# Altium schematic sheet D5200_V002_3_20180610.SchDoc(D5200_V002_3_20180610)
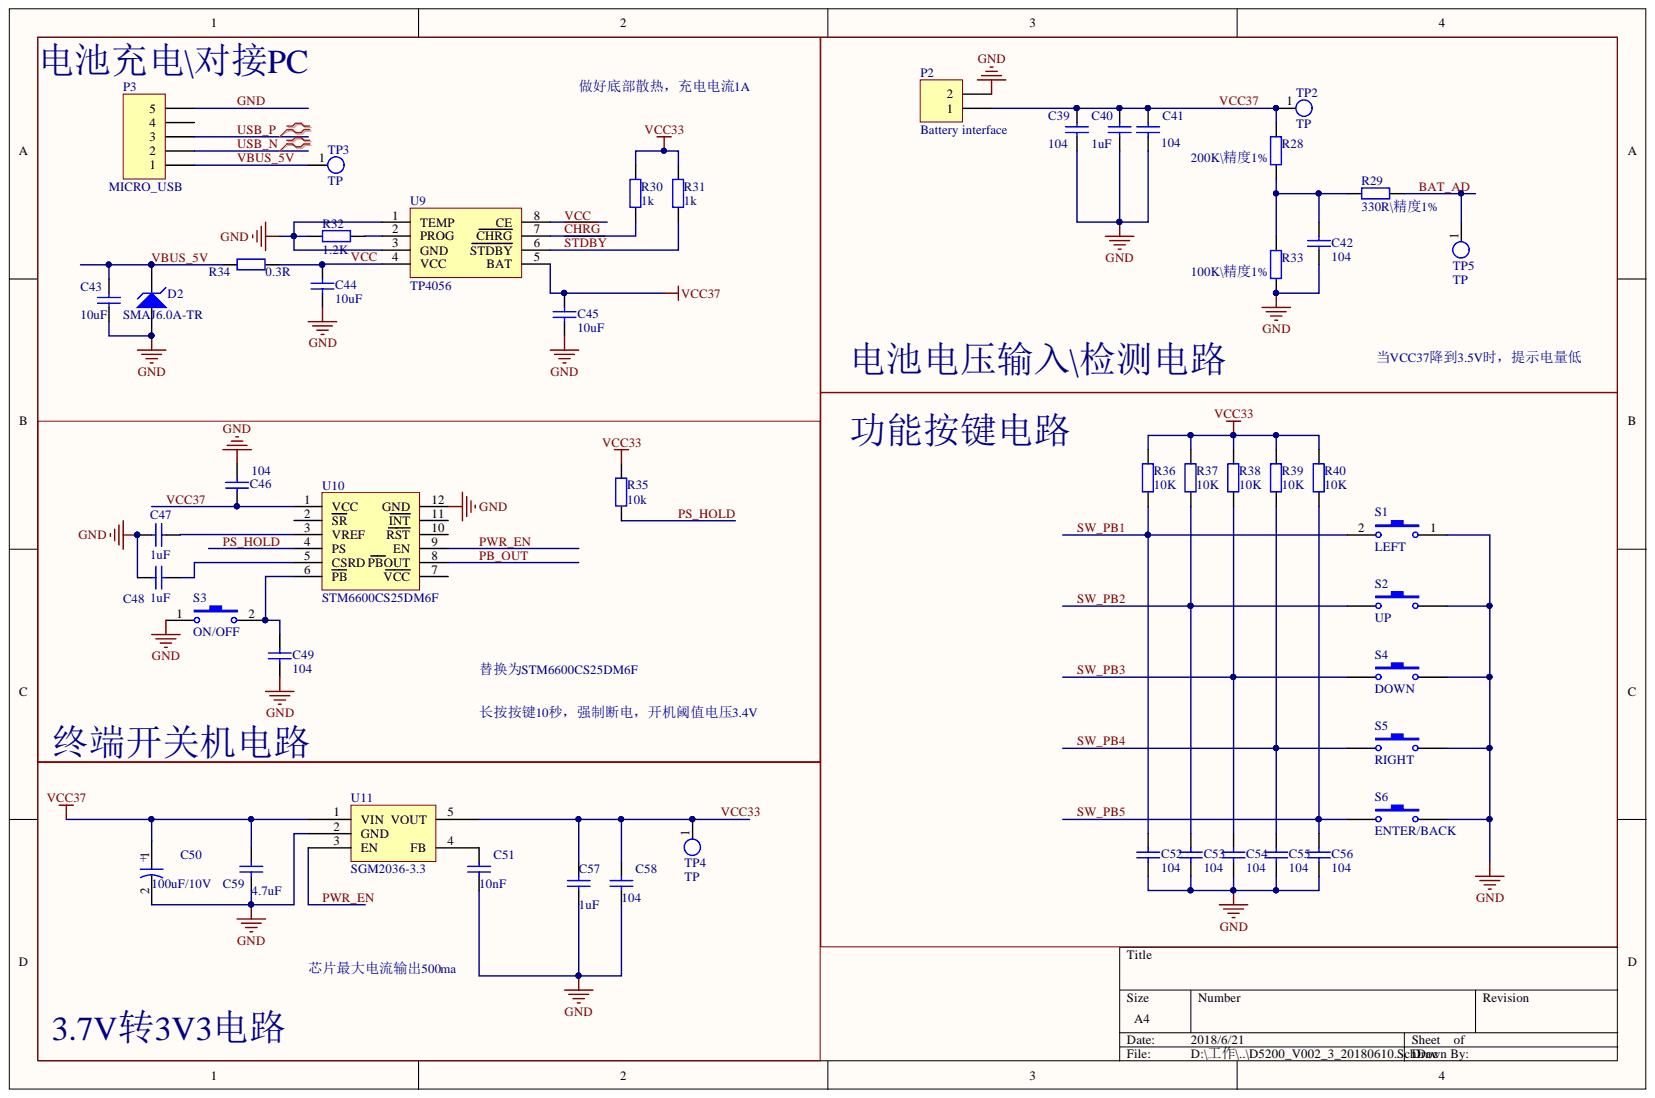
[diagram p0: D5200_V002_3_20180610.SchDoc]

## Parts
C39  104  [0402C]
C40  1uF  [0402C]
C41  104  [0402C]
C42  104  [0402C]
C43  10uF  [0402C]
C44  10uF  [0402C]
C45  10uF  [0402C]
C46  104  [0402C]
C47  1uF  [0402C]
C48  1uF  [0402C]
C49  104  [0402C]
C50  100uF/10V  [LC-CASE-B_3528]
C51  10nF  [0402C]
C52  104  [0402C]
C53  104  [0402C]
C54  104  [0402C]
C55  104  [0402C]
C56  104  [0402C]
C57  1uF  [0402C]
C58  104  [0402C]
C59  4.7uF  [0402C]
D2  SMAJ6.0A-TR  [SMA/DO-214AC]
P2  Battery interface  [HDR1X2]
P3  MICRO_USB  [USB5SA]
R28  200K  [0402R]  (value not drawn)
R29  330R  [0402R]
R30  1k  [0402R]
R31  1k  [0402R]
R32  1.2K  [0402R]
R33  100K  [0402R]  (value not drawn)
R34  0.3R  [1210]
R35  10k  [0402R]
R36  10K  [0402R]
R37  10K  [0402R]
R38  10K  [0402R]
R39  10K  [0402R]
R40  10K  [0402R]
S1  LEFT  [BUTTON1]
S2  UP  [BUTTON1]
S3  ON/OFF  [BUTTON1]
S4  DOWN  [BUTTON1]
S5  RIGHT  [BUTTON1]
S6  ENTER/BACK  [BUTTON1]
TP2  TP  [TP]
TP3  TP  [TP]
TP4  TP  [TP]
TP5  TP  [TP]
U9  TP4056  [SOP8-Pad]
U10  STM6600CS25DM6F  [TDFN12]
U11  SGM2036-3.3  [sot23-5]

## Nets
BAT_AD: R29.2 TP5.1
~{CHRG}: R30.1 U9.7(CHRG)
GND: C39.1 C40.1 C41.1 C42.1 C43.1 C44.1 C45.1 C46.1 C47.2 C48.2 C49.1 C50.2 C51.1 C52.1 C53.1 C54.1 C55.1 C56.1 C57.2 C58.1 C59.2 D2.1 P2.2 P3.5 R32.2 R33.2 S1.1 S2.1 S3.1 S4.1 S5.1 S6.1 U9.1(TEMP) U9.3(GND) U10.12(GND) U11.2(GND)
PB_OUT: U10.8(PBOUT)
PS_HOLD: R35.1 U10.4(PS)
PWR_EN: U10.9(EN) U11.3(EN)
~{STDBY}: R31.1 U9.6(STDBY)
SW_PB1: C52.2 R36.2 S1.2
SW_PB2: C53.2 R37.2 S2.2
SW_PB3: C54.2 R38.2 S4.2
SW_PB4: C55.2 R39.2 S5.2
SW_PB5: C56.2 R40.2 S6.2
USB_N: P3.2
USB_P: P3.3
VBUS_5V: C43.2 D2.2 P3.1 R34.1 TP3.1
VCC: C44.2 R34.2 U9.4(VCC) U9.8(CE)
VCC33: C57.1 C58.2 R30.2 R31.2 R35.2 R36.1 R37.1 R38.1 R39.1 R40.1 TP4.1 U11.5(VOUT)
VCC37: C39.2 C40.2 C41.2 C45.2 C46.2 C50.1 C59.1 P2.1 R28.1 TP2.1 U9.5(BAT) U10.1(VCC) U11.1(VIN)
NetC42_2: C42.2 R28.2 R29.1 R33.1
NetC47_1: C47.1 U10.3(VREF)
NetC48_1: C48.1 U10.5(CSRD)
NetC49_2: C49.2 S3.2 U10.6(PB)
NetC51_2: C51.2 U11.4(FB)
NetR32_1: R32.1 U9.2(PROG)

Not connected: P3 4; U10 2(SR) 7(VCC) 10(RST) 11(INT)

## Library data of the parts
C39-C49 C52-C57 C59 = 0201: Component Kind: Standard
  C39 C41 C42 C46 C49 C52-C56: Value: 104
  C40 C47 C48 C57: Value: 1uF
  C43-C45: Value: 10uF
  C59: Value: 4.7uF
C50 = 02050003: 'TAJB107M010RNJ; Component Kind: Standard; Value: 100uF/10V
C51 C58 = Cap: Capacitor; Component Kind: Standard; Library Name: Miscellaneous Devices.IntLib
  C51: Value: 10nF
  C58: Value: 104
D2 = D Zener: Zener Diode; Component Kind: Standard; LatestRevisionDate: 17-Jul-2002; LatestRevisionNote: Re-released for DXP Platform.; Library Name: Miscellaneous Devices.IntLib; PackageDescription: Diode, Thru-Hole; 2 Leads; 0.7 in Pin Spacing; PackageReference: DIODE-0.7; Published: 8-Jun-2000; Publisher: Altium Limited
P2 = Header 2: Header, 2-Pin; Component Kind: Standard; LatestRevisionDate: 17-Jul-2002; LatestRevisionNote: Re-released for DXP Platform.; Library Name: Miscellaneous Connectors.IntLib; Signal Integrity: Connector; Publisher: Altium Limited
P3 = Header 5: Header, 5-Pin; Component Kind: Standard; LatestRevisionDate: 17-Jul-2002; LatestRevisionNote: Re-released for DXP Platform.; Library Name: Miscellaneous Connectors.IntLib; Publisher: Altium Limited
R28 = Res2: Resistor; Value: 200K\精度1%; Component Kind: Standard; Library Name: Miscellaneous Devices.IntLib
R29 = Res2: Resistor; Value: 330R\精度1%; Component Kind: Standard; Library Name: Miscellaneous Devices.IntLib
R30-R32 R34-R40 = Res2: Resistor; Component Kind: Standard; Library Name: Miscellaneous Devices.IntLib
  R30 R31: Value: 1k
  R32: Value: 1.2K
  R34: Value: 0.3R
  R35: Value: 10k
  R36-R40: Value: 10K
R33 = Res2: Resistor; Value: 100K\精度1%; Component Kind: Standard; Library Name: Miscellaneous Devices.IntLib
S1-S6 = SW-PB: 锅仔片; Component Kind: Standard; LatestRevisionDate: 17-Jul-2002; LatestRevisionNote: Re-released for DXP Platform.; Library Name: Miscellaneous Devices.IntLib; PackageDescription: Switch; 2 Leads; PackageReference: SPST-2; Published: 24-Mar-1999; Publisher: Altium Limited
U10 = STM6600: Component Kind: Standard; Library Name: 参考设计库.SchLib
U11 = SGM2028: Component Kind: Standard; Library Name: 参考设计库.SchLib
TP2-TP5 = TP: Component Kind: Standard; Library Name: 参考设计库.SchLib
U9 = TP4056: Component Kind: Standard; Library Name: 参考设计库.SchLib
